# Altium schematic sheet SwitchingCircuit.SchDoc(SwitchingCircuit)
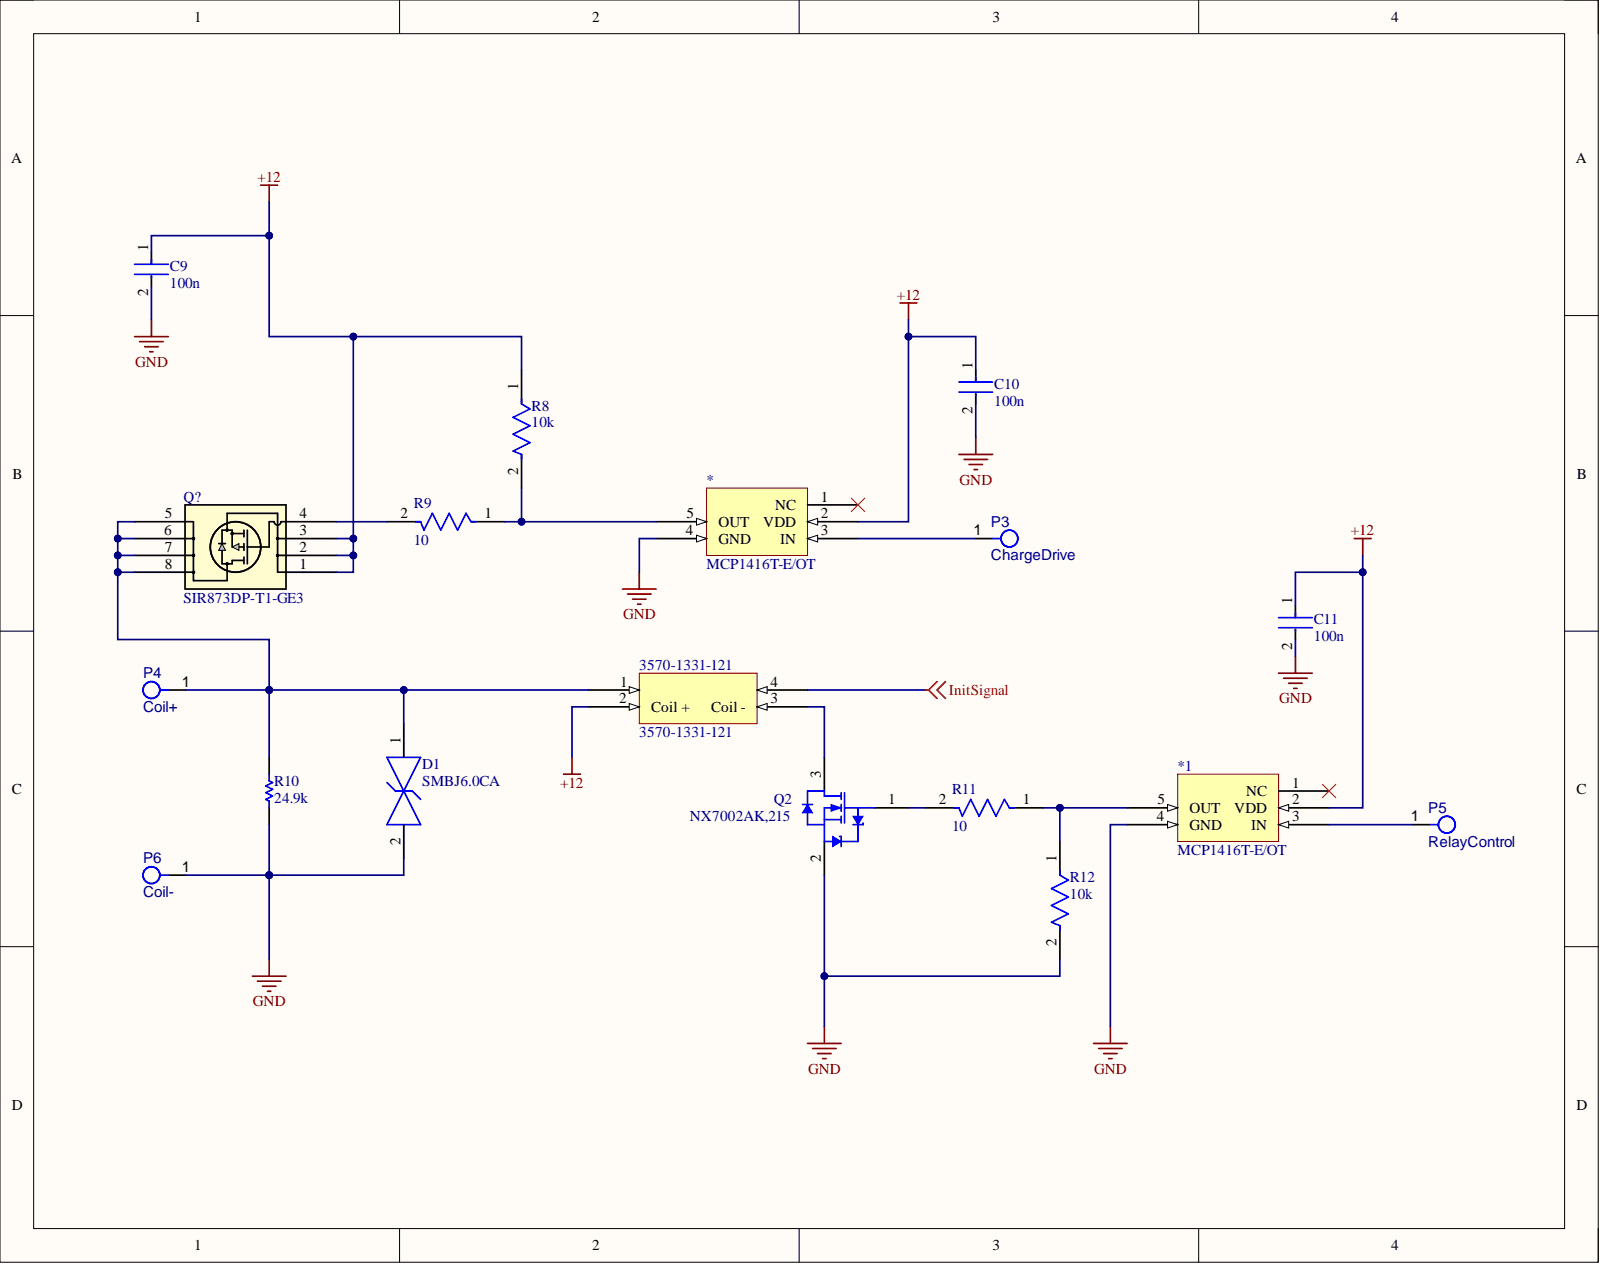
[diagram p0: SwitchingCircuit.SchDoc]

## Parts
3570-1331-121  3570-1331-121
*  MCP1416T-E/OT
*1  MCP1416T-E/OT
C9  100n
C10  100n
C11  100n
D1  SMBJ6.0CA
P3  ChargeDrive  (no BOM)
P4  Coil+  (no BOM)
P5  RelayControl  (no BOM)
P6  Coil-  (no BOM)
Q2  NX7002AK,215
Q?  SIR873DP-T1-GE3
R8  10k
R9  10
R10  24.9k
R11  10
R12  10k

## Nets
+12: 3570-1331-121.2(Coil_+) *.2(VDD) *1.2(VDD) C9.1 C10.1 C11.1 Q?.1 Q?.2 Q?.3 R8.1
GND: *.4(GND) *1.4(GND) C9.2 C10.2 C11.2 D1.2 P6.1 Q2.2 R10.1 R12.2
InitSignal: 3570-1331-121.4
Net3570-1331-121_1: 3570-1331-121.1 D1.1 P4.1 Q?.5 Q?.6 Q?.7 Q?.8 R10.2
Net3570-1331-121_3: 3570-1331-121.3(Coil_-) Q2.3
Net*1_3: *1.3(IN) P5.1
Net*1_5: *1.5(OUT) R11.1 R12.1
Net*_3: *.3(IN) P3.1
Net*_5: *.5(OUT) R8.2 R9.1
NetQ2_1: Q2.1 R11.2
NetQ?_4: Q?.4 R9.2

Not connected: * 1(NC); *1 1(NC)

## Library data of the parts
3570-1331-121 = CMP-008-00005-1: Relay Reed Sip SPST .5A 12V; Component Kind: Standard; Library Name: James Brasfield
* *1 = CMP-00024-00006-1: IC GATE DRVR LOW-SIDE SOT23-5 Low-Side Gate Driver IC Non-Inverting SOT-23-5; Nominal Supply Current: 150µA; Case/Package: SOT-23; Component Kind: Standard; Max Operating Temperature: 125°C; Min Operating Temperature: -40°C; Mounting Technology: Surface Mount; Pins: 5; Library Name: James Brasfield
C9-C11 = CMP-06035-028588-1: Chip Multilayer Ceramic Capacitors for General Purpose, 1206, 0.10uF, C0G, 30ppm/°C, 5%, 50V; Component Kind: Standard; Library Name: Altium Content Vault
D1 = CMP-08607-000029-1: TVS DIODE 6V 10.3V DO214AA; Manufacturer: Littelfuse Inc; Breakdown Voltage: 6.67V; Direction: Bidirectional; Max Junction Temp: +150°C; Number Of Channels: 1; Peak Pulse Current: 58.3A; Peak Pulse Power: 600W; Automotive: No; Category: Diode; Clamping Voltage: 10.3V; Component Kind: Standard; ComponentLink2Description: Datasheet; ComponentLink2URL: https://www.littelfuse.com/~/media/electronics/datasheets/tvs_diodes/littelfuse_tvs_diode_smbj_datasheet.pdf.pdf; Device Class L1: Circuit Protection; Device Class L2: TVS Diodes; Device Class L3: unset; Digikey Description: TVS DIODE 6V 10.3V DO214AA; Height: 2.61mm; Lead Free: Yes; Library Name: Altium Content Vault; Manufacturer Part Number: SMBJ6.0CA; Mouser Description: TVS Diodes - Transient Voltage Suppressors 60Vr 600W 6.2A 5% BiDirectional; Octopart: https://octopart.com/smbj6.0ca-littelfuse-351021; Package: SMBJ; Reverse Standoff Voltage: 6V; RoHS: TRUE; Temperature: -65°C to +150°C; Temperature Range High: +150°C; Temperature Range Low: -65°C
P3-P6 = CMP-019-00043-3: SMD WIRE PAD 7 x 4 mm; Pins: 1; Library Name: James Brasfield
Q2 = CMP-1258-00137-1: Single N-Channel Trench MOSFET, 60 V, -55 to 150 degC, 3-Pin SOT23, Tape and Reel; Manufacturer: NXP Semiconductors; Channel Type: N; Ciss [typ] (pF): 11; Coss [typ] (pF): 3.4; Packing: Tape & Reel; VDS [max] (V): 60; VGSth [typ] (V): 1.6; Code_JEDEC: TO-236AB; Component Kind: Standard; ComponentLink1Description: Manufacturer URL; ComponentLink1URL: http://www.nxp.com/; ComponentLink2Description: Datasheet; ComponentLink2URL: http://www.nxp.com/documents/data_sheet/NX7002AK.pdf; ComponentLink3Description: Package Specification; ComponentLink3URL: http://www.nxp.com/documents/outline_drawing/sot023_po.pdf; DatasheetVersion: Rev. 5; Library Name: Altium Content Vault; Mounting Technology: Surface Mount; PackageDescription: 3-Pin Small Outline Transistor Package, Body 2.9 x 1.3 mm, Pitch 0.95 mm; PackageReference: SOT23; PackageVersion: 06-03-16, 03/2006; PartNumber: NX7002AK,215
Q? = CMP-027-000000-1: P-CHANNEL 150-V (D-S) MOSFET; Manufacturer_Name: Vishay; Component Kind: Standard; Design Item ID: SIR873DP-T1-GE3; Manufacturer_Part_Number: SIR873DP-T1-GE3; RefDes: RefDes; Source: SIR873DP-T1-GE3.SchLib; Type: Type; Copyright: Copyright (C) 2025 Ultra Librarian. All rights reserved.; Library Name: James Brasfield
R8 R12 = CMP-2000-06990-2: 10kΩ ±1% 0.1W 0603 Thick Film Chip Resistor AEC-Q200 compliant; Max Power Dissipation: 100mW; Resistance: 10kR; Temperature Coefficient: 100ppm/°C; Case Code (Imperial): 0603; Case Code (Metric): 1608; Case/Package: 0603; Component Kind: Standard; Construction: Rectangular; Contact Plating: Tin; Depth: 0.8mm; Features: Automotive AEC-Q200; Height: 0.022inch; Lead Free: Lead Free; Length: 1.55mm; Library Name: Altium Content Vault; Max Operating Temperature: 155°C; Military Standard: Not; Min Operating Temperature: -55°C; Mount: Surface Mount; Number of Terminations: 2; Packaging: Tape and Reel; Power Rating: 100mW; Radiation Hardening: No; Resistor Type: Thick Film; RoHS Compliant: Yes; Series: RMCF; Size Code: 0603; Tolerance: 1%; Width: 0.031inch
R9 R11 = CMP-26527-005040-1: 10Ω ±1% 0.1W 0603 Thick Film Chip Resistor AEC-Q200 compliant; Max Power Dissipation: 100mW; Resistance: 10R; Temperature Coefficient: 100ppm/°C; Case Code (Imperial): 0603; Case Code (Metric): 1608; Case/Package: 0603; Component Kind: Standard; Construction: Rectangular; Contact Plating: Tin; Depth: 0.8mm; Features: Automotive AEC-Q200; Height: 0.022inch; Length: 1.55mm; Library Name: Altium Content Vault; Max Operating Temperature: 155°C; Military Standard: Not; Min Operating Temperature: -55°C; Mount: Surface Mount; Number of Terminations: 2; Packaging: Tape and Reel; Power Rating: 100mW; Radiation Hardening: No; Resistor Type: Thick Film; RoHS Compliant: Yes; Series: RMCF; Tolerance: 1%; Width: 0.031inch
R10 = CMP-2001-03716-1: Manufacturer: Vishay Dale; Part Number: CRCW080524K9FKEA; Component Kind: Standard; Library Name: Altium Content Vault
